# Altium schematic sheet Reflective_Sensors.SchDoc(Reflective_Sensors)
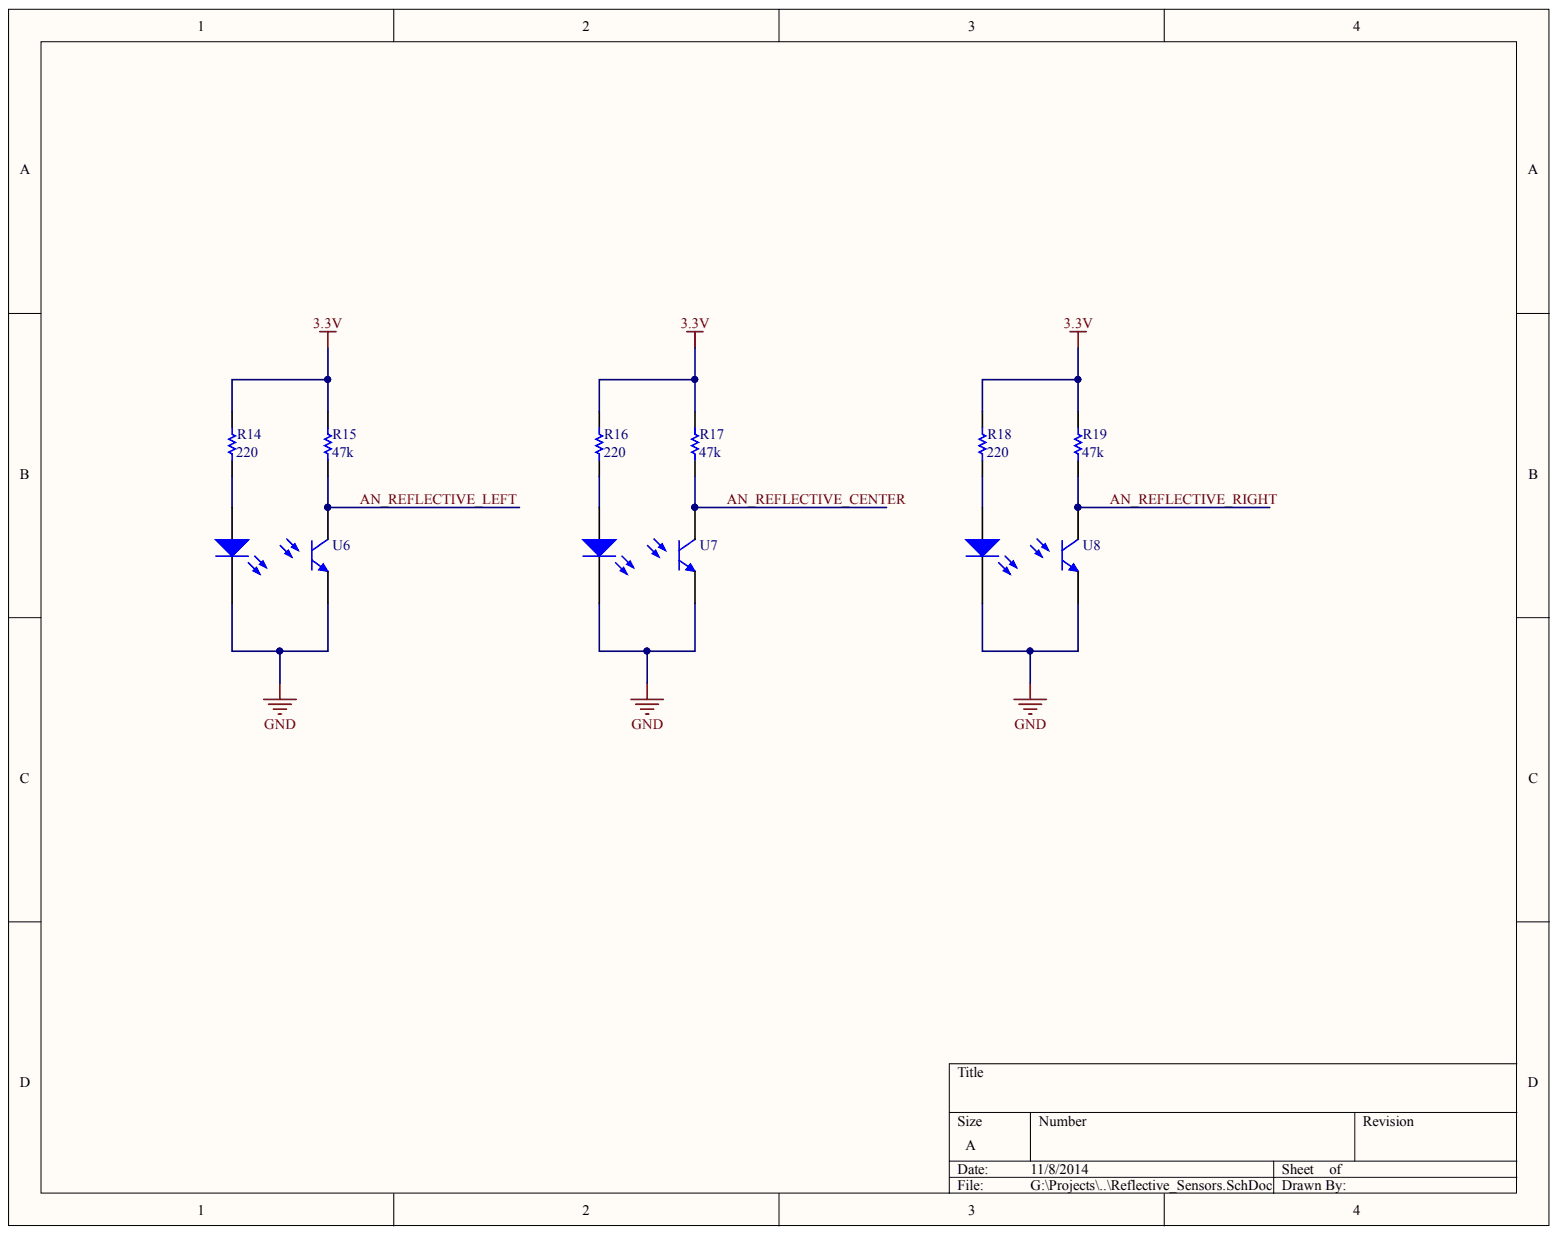
[diagram p0: Reflective_Sensors.SchDoc]

## Parts
R14  value 220  [0805]  (hidden comment: Resistor)
R15  value 47k  [0805]  (hidden comment: Resistor)
R16  value 220  [0805]  (hidden comment: Resistor)
R17  value 47k  [0805]  (hidden comment: Resistor)
R18  value 220  [0805]  (hidden comment: Resistor)
R19  value 47k  [0805]  (hidden comment: Resistor)
U6  QRE1113GR  [QRE1113GR]  (value not drawn)
U7  QRE1113GR  [QRE1113GR]  (value not drawn)
U8  QRE1113GR  [QRE1113GR]  (value not drawn)

## Nets
3.3V: R14.2 R15.2 R16.2 R17.2 R18.2 R19.2
GND: U6.2 U6.4 U7.2 U7.4 U8.2 U8.4
AN_REFLECTIVE_CENTER: R17.1 U7.3
AN_REFLECTIVE_LEFT: R15.1 U6.3
AN_REFLECTIVE_RIGHT: R19.1 U8.3
NetR14_1: R14.1 U6.1
NetR16_1: R16.1 U7.1
NetR18_1: R18.1 U8.1

## Library data of the parts
R14-R19 = Resistor: Component Kind: Standard; Description: Resistor; LatestRevisionDate: 17-Jul-2002; LatestRevisionNote: Re-released for DXP Platform.; Library Name: passives.SchLib; PackageReference: AXIAL-0.3; Published: 8-Jun-2000; Publisher: Altium Limited
U6-U8 = QRE1113GR: Component Kind: Standard; Library Name: Devices.SchLib
